# Altium schematic sheet Core.SchDoc(Core)
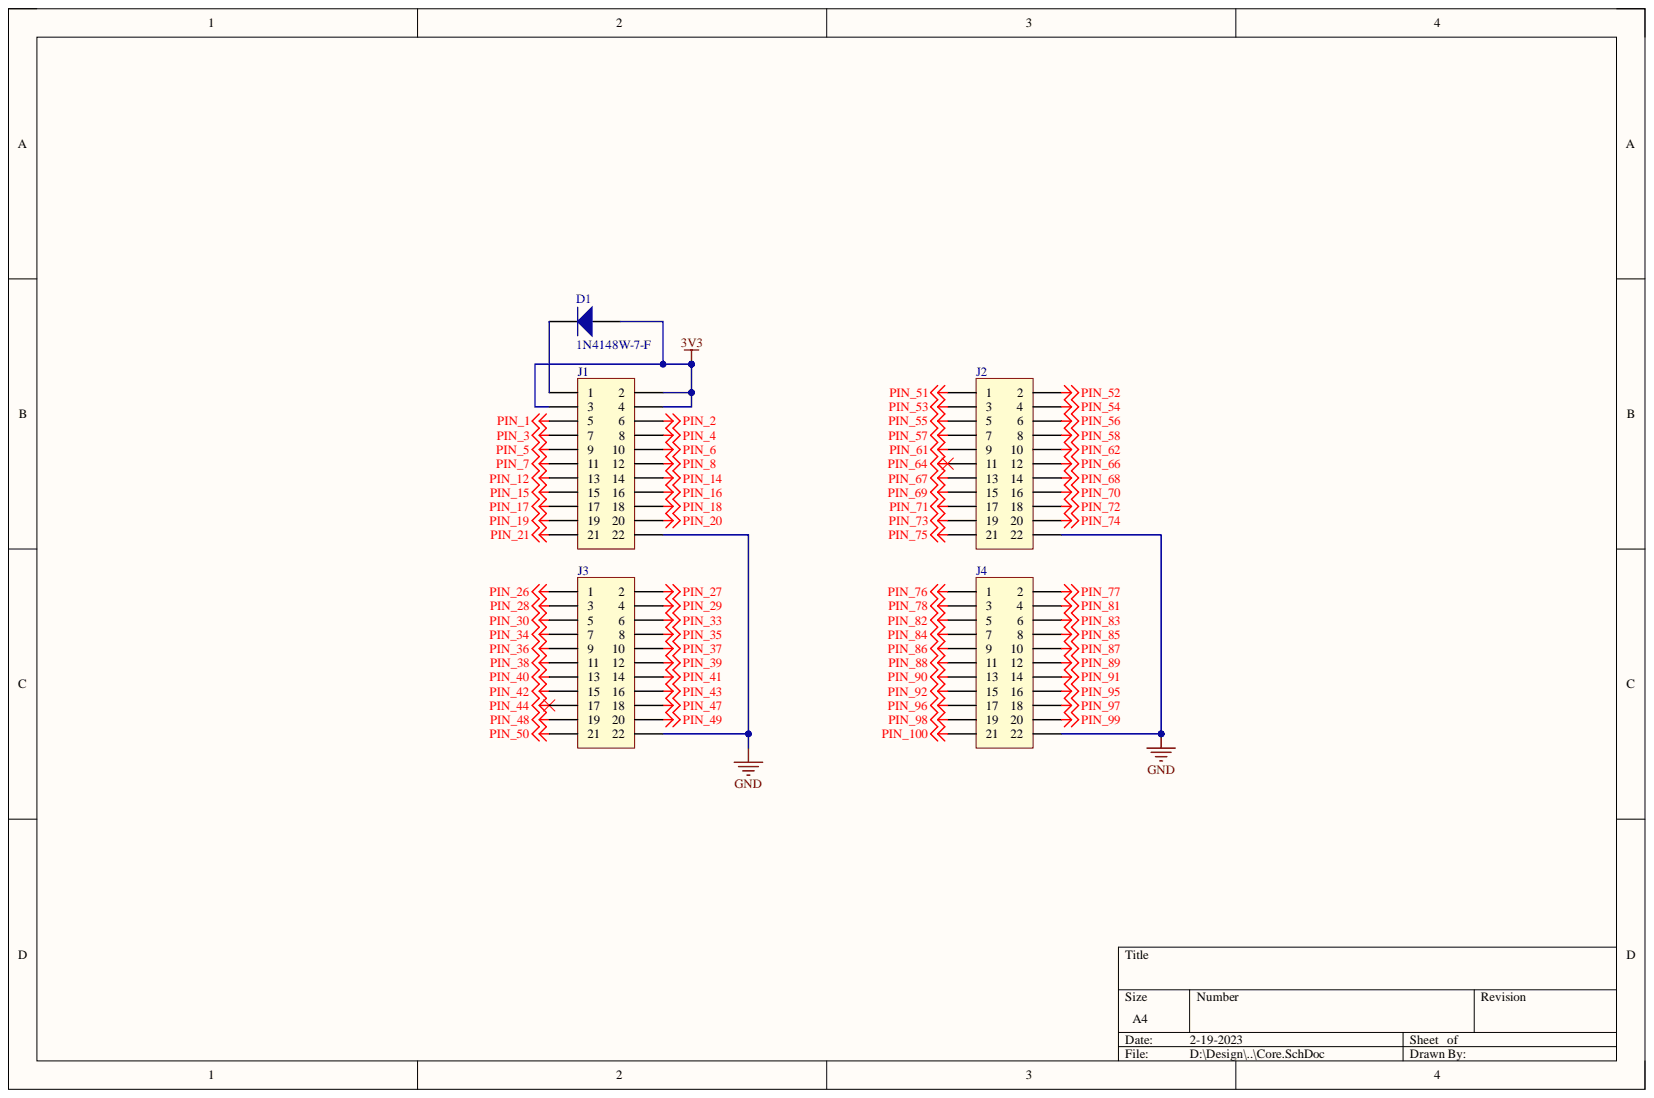
[diagram p0: Core.SchDoc]

## Parts
D1  1N4148W-7-F  [DIOD-SOD123-2_V]
J1  61302221821  [61302221821_F]  (value not drawn)
J2  61302221821  [61302221821_F]  (value not drawn)
J3  61302221821  [61302221821_F]  (value not drawn)
J4  61302221821  [61302221821_F]  (value not drawn)

## Nets
3V3: D1.1 J1.2 J1.3 J1.4
GND: J1.22 J2.22 J3.22 J4.22
PIN_1: J1.5
PIN_2: J1.6
PIN_3: J1.7
PIN_4: J1.8
PIN_5: J1.9
PIN_6: J1.10
PIN_7: J1.11
PIN_8: J1.12
PIN_12: J1.13
PIN_14: J1.14
PIN_15: J1.15
PIN_16: J1.16
PIN_17: J1.17
PIN_18: J1.18
PIN_19: J1.19
PIN_20: J1.20
PIN_21: J1.21
PIN_26: J3.1
PIN_27: J3.2
PIN_28: J3.3
PIN_29: J3.4
PIN_30: J3.5
PIN_33: J3.6
PIN_34: J3.7
PIN_35: J3.8
PIN_36: J3.9
PIN_37: J3.10
PIN_38: J3.11
PIN_39: J3.12
PIN_40: J3.13
PIN_41: J3.14
PIN_42: J3.15
PIN_43: J3.16
PIN_44: J3.17
PIN_47: J3.18
PIN_48: J3.19
PIN_49: J3.20
PIN_50: J3.21
PIN_51: J2.1
PIN_52: J2.2
PIN_53: J2.3
PIN_54: J2.4
PIN_55: J2.5
PIN_56: J2.6
PIN_57: J2.7
PIN_58: J2.8
PIN_61: J2.9
PIN_62: J2.10
PIN_64: J2.11
PIN_66: J2.12
PIN_67: J2.13
PIN_68: J2.14
PIN_69: J2.15
PIN_70: J2.16
PIN_71: J2.17
PIN_72: J2.18
PIN_73: J2.19
PIN_74: J2.20
PIN_75: J2.21
PIN_76: J4.1
PIN_77: J4.2
PIN_78: J4.3
PIN_81: J4.4
PIN_82: J4.5
PIN_83: J4.6
PIN_84: J4.7
PIN_85: J4.8
PIN_86: J4.9
PIN_87: J4.10
PIN_88: J4.11
PIN_89: J4.12
PIN_90: J4.13
PIN_91: J4.14
PIN_92: J4.15
PIN_95: J4.16
PIN_96: J4.17
PIN_97: J4.18
PIN_98: J4.19
PIN_99: J4.20
PIN_100: J4.21
NetD1_2: D1.2 J1.1

## Library data of the parts
D1 = CMP-016-0032-1: VR=100V IF=300mA Trr=4ns P=400mW; Average Rectified Current: 300mA; Capacitance: 2pF; Current Rating: 150mA; Element Configuration: Single; Forward Voltage: 1.25V; Max Forward Surge Current (Ifsm): 2A; Max Repetitive Reverse Voltage (Vrrm): 100V; Max Reverse Current: 1uA; Polarity: Standard; Power Dissipation: 400mW; Recovery Time: 4ns; Voltage Rating (DC): 75V; Component Kind: Standard; Contact Plating: Tin,Matte; Forward Current: 150mA; Height: 1.25mm; Lead Free: Lead Free; Length: 2.85mm; Library Name: C6H6`s Vault; Max Operating Temperature: 150°C; Max Reverse Voltage (DC): 100V; Max Surge Current: 2A; Min Operating Temperature: -65°C; Mount: Surface Mount; Number of Pins: 2; Output Current: 150mA; Package Quantity: 3000; Packaging: Tape and Reel; Peak Non-Repetitive Surge Current: 2A; Power Rating: 400mW; Radiation Hardening: No; Reach SVHC Compliant: Yes; Reverse Recovery Time: 4ns; Reverse Recovery Time-Max: 4ns; RoHS Compliant: Yes; Series: -; Voltage: 100V; Weight: 0.000353oz; Width: 1.7mm
J1-J4 = CMP-00200-2: Socket Header WR-PHD, Pitch 2.54 mm, THT, Vertical, dual row, 22 pin; Contact Resistance: 20 mOhm; Insulation Resistance: >1000 MOhm; Pin Number: 22; Pitch: 2.54 mm; Rated Current: 3 A; Working Voltage: 250 VAC; Component Kind: Standard; ComponentLink1Description: Manufacturer; ComponentLink1URL: http://www.we-online.de; ComponentLink2Description: Datasheet; ComponentLink2URL: http://katalog.we-online.com/em/datasheet/6130xx21821.pdf; Library Name: C6H6`s Vault; Mounting Technology: THT; Operating Temperature: -40 to 125 degC; Orientation: Vertical; Part Number: 61302221821; Published Date: Jan. 2018; Row Number: dual; SchLibComponentName: 61302221821_F; Publisher: Wurth Elektronik
